# Altium schematic sheet Microcontroller.SchDoc
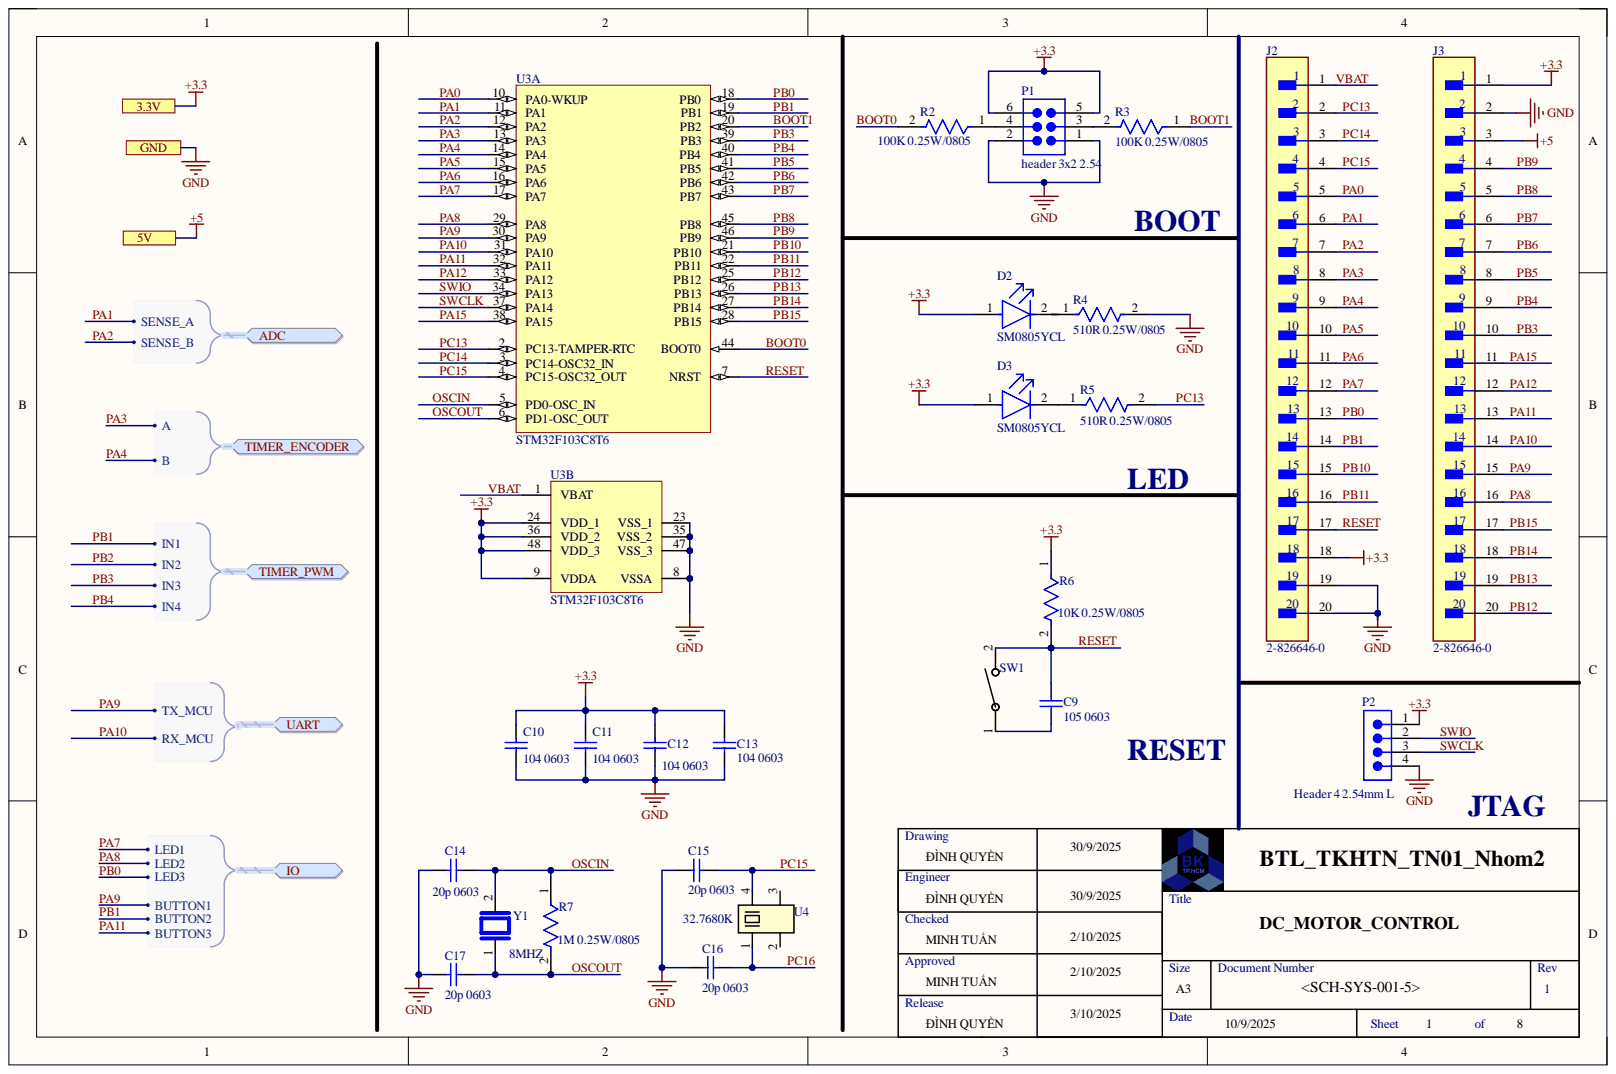
[diagram p0: Microcontroller.SchDoc]

## Parts
C9  105 0603  [CAPC1608X92X38ML15T08]
C10  104 0603  [CAPC1608X92X38ML15T08]
C11  104 0603  [CAPC1608X92X38ML15T08]
C12  104 0603  [CAPC1608X92X38ML15T08]
C13  104 0603  [CAPC1608X92X38ML15T08]
C14  20p 0603  [CAPC1608X92X38ML15T08]
C15  20p 0603  [CAPC1608X92X38ML15T08]
C16  20p 0603  [CAPC1608X92X38ML15T08]
C17  20p 0603  [CAPC1608X92X38ML15T08]
D2  SM0805YCL  [FP-SM0805YCL-MFG]
D3  SM0805YCL  [FP-SM0805YCL-MFG]
J2  2-826646-0  [FP-2-826646-0-MFG]
J3  2-826646-0  [FP-2-826646-0-MFG]
P1  header 3x2 2.54  [SMTC-TSW-103-08-X-D]
P2  Header 4 2.54mm L  [SMTC-TSW-104-08-X-S]
R2  100K 0.25W/0805  [FP-AF0805-IPC_C]
R3  100K 0.25W/0805  [FP-AF0805-IPC_C]
R4  510R 0.25W/0805  [FP-AF0805-IPC_C]
R5  510R 0.25W/0805  [FP-AF0805-IPC_C]
R6  10K 0.25W/0805  [FP-AF0805-IPC_C]
R7  1M 0.25W/0805  [FP-AF0805-IPC_C]
SW1  PTS636 SM50J SMTR LFS  [SW_PTS636_CNK]  (value not drawn)
U3  STM32F103C8T6  [STM-LQFP48_L]  (parts A,B)
U4  32.7680K  [SOJ_MC-306_EPS]
Y1  8MHZ  [XTAL_HC49P488W43L1150T466H350]

## Ports
3.3V, 5V, ADC, ADC.SENSE_A, ADC.SENSE_B, GND, IO, IO.BUTTON1, IO.BUTTON2, IO.BUTTON3, IO.LED1, IO.LED2, IO.LED3, TIMER_ENCODER, TIMER_ENCODER.A, TIMER_ENCODER.B, TIMER_PWM, TIMER_PWM.IN1, TIMER_PWM.IN2, TIMER_PWM.IN3, TIMER_PWM.IN4, UART, UART.RX_MCU, UART.TX_MCU

## Nets
+3.3: C10.2 C11.2 C12.2 C13.2 D2.1 D3.1 J2.18 J3.1 P1.5 P1.6 P2.1 R6.1 U3.9(VDDA) U3.24(VDD_1) U3.36(VDD_2) U3.48(VDD_3) ; port 3.3V
+5: J3.3 ; port 5V
BOOT0: R2.2 U3.44(BOOT0)
BOOT1: R3.1 U3.20(PB2)
GND: C10.1 C11.1 C12.1 C13.1 C14.2 C15.2 C16.2 C17.2 J2.19 J2.20 J3.2 P1.1 P1.2 P2.4 R4.2 U3.8(VSSA) U3.23(VSS_1) U3.35(VSS_2) U3.47(VSS_3) ; port GND
OSCIN: C14.1 R7.1 U3.5(PD0-OSC_IN) Y1.2
OSCOUT: C17.1 R7.2 U3.6(PD1-OSC_OUT) Y1.1
PA0: J2.5 U3.10(PA0-WKUP)
PA1: J2.6 U3.11(PA1) ; port ADC
PA2: J2.7 U3.12(PA2) ; port ADC
PA3: J2.8 U3.13(PA3) ; port TIMER_ENCODER
PA4: J2.9 U3.14(PA4) ; port TIMER_ENCODER
PA5: J2.10 U3.15(PA5)
PA6: J2.11 U3.16(PA6)
PA7: J2.12 U3.17(PA7) ; port IO
PA8: J3.16 U3.29(PA8) ; port IO
PA9: J3.15 U3.30(PA9) ; port IO, port UART
PA10: J3.14 U3.31(PA10) ; port UART
PA11: J3.13 U3.32(PA11) ; port IO
PA12: J3.12 U3.33(PA12)
PA15: J3.11 U3.38(PA15)
PB0: J2.13 U3.18(PB0) ; port IO
PB1: J2.14 U3.19(PB1) ; port IO, port TIMER_PWM
PB3: J3.10 U3.39(PB3) ; port TIMER_PWM
PB4: J3.9 U3.40(PB4) ; port TIMER_PWM
PB5: J3.8 U3.41(PB5)
PB6: J3.7 U3.42(PB6)
PB7: J3.6 U3.43(PB7)
PB8: J3.5 U3.45(PB8)
PB9: J3.4 U3.46(PB9)
PB10: J2.15 U3.21(PB10)
PB11: J2.16 U3.22(PB11)
PB12: J3.20 U3.25(PB12)
PB13: J3.19 U3.26(PB13)
PB14: J3.18 U3.27(PB14)
PB15: J3.17 U3.28(PB15)
PC13: J2.2 R5.2 U3.2(PC13-TAMPER-RTC)
PC14: J2.3 U3.3(PC14-OSC32_IN)
PC15: C15.1 J2.4 U3.4(PC15-OSC32_OUT) U4.4
PC16: C16.1 U4.1
RESET: C9.2 J2.17 R6.2 SW1.2 U3.7(NRST)
SWCLK: P2.3 U3.37(PA14)
SWIO: P2.2 U3.34(PA13)
VBAT: J2.1 U3.1(VBAT)
NetC9_1: C9.1 SW1.1
NetD2_2: D2.2
NetD3_2: D3.2 R5.1
NetP1_3: P1.3 R3.2
NetP1_4: P1.4 R2.1
NetR4_1: R4.1
NetU4_2: U4.2
NetU4_3: U4.3
PB2: ; port TIMER_PWM

## Library data of the parts
C9-C17 = CMP-2006-01201-1: Manufacturer: Vitramon; Part Number: VJ0603Y103JXXAC; Component Kind: Standard; Library Name: Altium Content Vault
D2 D3 = CMP-35283-000007-1: LED YELLOW CLEAR 0805 SMD; Dominant Wavelength: 592nm; Forward Current: 2mA; Forward Voltage: 1.9V; Lens Color: Colorless; Luminous Intensity: 11.5mcd; Viewing Angle: 130°; Case Code (Imperial): 0805; Case Code (Metric): 2012; Case/Package: 0805; Color: Yellow; Component Kind: Standard; Height: 0.8mm; Illumination Color: Yellow; Lead Free: Lead Free; Length: 2mm; Lens Transparency: Clear; Library Name: Altium Content Vault; Max Operating Temperature: 80°C; Min Operating Temperature: -30°C; Mount: Surface Mount; Package Quantity: 4000; Packaging: Tape and Reel; Test Current: 2mA; Width: 1.2mm
J2 J3 = CMP-03369-000139-1: CONN HEADER VERT 20POS 2.54MM; Board Standoff: No (Without); Connector Type: Plug; Contact Post Length: 0.1259inch; Current Rating: 3A; High Speed Serial Data Connector: No; Number of Contacts: 20; PCB Mount Retention: Without; PCB Mounting Orientation: Vertical; Plating Thickness: 0.8um; Color: Green; Component Kind: Standard; Contact Gender: Male; Contact Material: Bronze; Contact Mating Length: 5.8mm; Contact Plating: Gold; Contact Protection: No (Without); Contact Shape: Square; Contact Type: Male Pin; Depth: 2.5mm; ELV: Compliant; Flammability Rating: UL94 V-0; Gasket: No (Without); High Temperature Housing: No; Housing Color: Green; Housing Material: PBT; Keyed: No; Length: 50.8mm; Library Name: Altium Content Vault; Mating Alignment: No (Without); Mating Connector Lock: No (Without); Max Operating Temperature: 105°C; Min Operating Temperature: -65°C; Mount: Straddle Mount; Number of Positions: 20; Number of Rows: 1; Orientation: Straight; Package Quantity: 300; Packaging: Carton; Pitch: 2.54mm; Radiation Hardening: No; RoHS Compliant: Yes; Row Spacing: 2.54mm; Selectively Loaded: No (Without); Series: AMPMODU MOD II; Stabilizers: No (Without); Strain Relief: No (Without); Termination: Through Hole
P1 = CMP-1024-00337-1: 0.025" SQ Post Header, Through-hole, Vertical, -55 to 125 degC, 2.54 mm Pitch, 6-Pin, Male, RoHS; Manufacturer: Samtec; PartNumber: TSW-103-08-F-D; Pitch: 2.54 mm; Component Kind: Standard; ComponentLink1Description: Manufacturer URL; ComponentLink1URL: http://www.samtec.com; ComponentLink2Description: Datasheet; ComponentLink2URL: http://www.samtec.com/documents/webfiles/pdf/TSW_TH.PDF; ComponentLink3Description: Package Specification; ComponentLink3URL: http://www.samtec.com/documents/webfiles/cpdf/TSW-XXX-XX-XXX-X-XX-XXX-MKT.pdf; DatasheetVersion: F-214; Library Name: Altium Content Vault; Mounting Technology: Through-hole; Orientation: Vertical; PackageDescription: .025[0.64] SQ. Post Terminal Strip Assembly; PackageReference: TSW-103-08-X-D; PackageVersion: DM, 8/1988; RoHS: True; Temperature Range: -55 to 125 degC
P2 = CMP-1024-00357-1: 0.025" SQ Post Header, Through-hole, Vertical, -55 to 125 degC, 2.54 mm Pitch, 4-Pin, Male, RoHS; Manufacturer: Samtec; PartNumber: TSW-104-08-G-S; Component Kind: Standard; ComponentLink1Description: Manufacturer URL; ComponentLink1URL: http://www.samtec.com; ComponentLink2Description: Datasheet; ComponentLink2URL: http://www.samtec.com/documents/webfiles/pdf/TSW_TH.PDF; ComponentLink3Description: Package Specification; ComponentLink3URL: http://www.samtec.com/documents/webfiles/cpdf/TSW-XXX-XX-XXX-X-XX-XXX-MKT.pdf; DatasheetVersion: F-214; Library Name: Altium Content Vault; Mounting Technology: Through-hole; Orientation: Vertical; PackageDescription: .025[0.64] SQ. Post Terminal Strip Assembly; PackageReference: TSW-104-08-X-S; PackageVersion: DM, 8/1988; Pitch: 2.54 mm; RoHS: True; Temperature Range: -55 to 125 degC
R2-R7 = CMP-03412-045285-1: Anti-Sulfurated Chip Resistor Automotive Grade 75Ω 1% 0.125W ±100ppm/°C 0805; Max Power Dissipation: 125mW; Resistance: 75R; Case/Package: 0805; Component Kind: Standard; Features: Moisture Resistant; Height: 0.024inch; Length: 0.079inch; Library Name: Altium Content Vault; Max Operating Temperature: 155°C; Min Operating Temperature: -55°C; Number of Terminations: 2; Packaging: Tape and Reel; Resistor Type: Thick Film; RoHS Compliant: Yes; Series: AF; Temperature Coefficient: 100ppm/°C; Tolerance: 1%; Width: 0.049inch
SW1 = PTS636 SM50J SMTR LFS: No Description Available; Manufacturer_Name: C&K Components; Component Kind: Standard; Library Name: PTS636SM50JSMTRLFS.IntLib; Manufacturer_Part_Number: PTS636 SM50J SMTR LFS; Type: Tactile Switches; Copyright: Copyright (C) 2025 Ultra Librarian. All rights reserved.
U3 = CMP-0237-00215-3: ARM Cortex-M3 32-bit MCU, 64 KB Flash, 20 KB Internal RAM, 37 I/Os, 48-pin LQFP, -40 to 85 degC, Tray; A/D Converter: 2x12-bit (10 Channel); FLASH Size (Prog) (kB): 64; I/Os (High Current): 37; Internal RAM Size (kB): 20; Operating Frequency(F) (Processor speed) (MHz): 72; Supply Voltage(Vcc) min (V): 2; Component Kind: Standard; ComponentLink1Description: Manufacturer URL; ComponentLink1URL: http://www.st.com/web/en/home.html; ComponentLink2Description: Datasheet; ComponentLink2URL: http://www.st.com/st-web-ui/static/active/en/resource/technical/document/datasheet/CD00161566.pdf; ComponentLink3Description: Package Specification; ComponentLink3URL: http://www.st.com/st-web-ui/static/active/en/resource/technical/document/datasheet/DM00058181.pdf; Core: ARM Cortex-M3; DatasheetVersion: Rev. 16; Library Name: Altium Content Vault; Mounting Technology: Surface Mount; PackageDescription: 48-Pin Low Profile Quad Flat Package, 7 x 7 mm Body, 0.5 mm Pitch; PackageReference: LQFP48; PackageVersion: Rev. 6, 06/2013; Packing: Tray; PartNumber: STM32F103C8T6
U4 = MC-306 32.7680K-A3: ROHS: No Description Available; Manufacturer_Name: Epson; Component Kind: Standard; Manufacturer_Part_Number: MC-306 32.7680K-A3: ROHS; RefDes: RefDes; Type: Type; Copyright: Copyright (C) 2025 Ultra Librarian. All rights reserved.; Library Name: MC-30632P7680K-A3&colon_ROHS.IntLib
Y1 = HC-49/U-S16000000ABJB: 16 MHz Â±30ppm Crystal 18pF 50 Ohms HC-49/US; MF: Citizen Finedevice; Check_prices: https://www.snapeda.com/parts/HC-49/U-S16000000ABJB/Citizen/view-part/?ref=eda; Component Kind: Standard; Library Name: HC-49_U-S16000000ABJB.IntLib; MP: HC-49/U-S16000000ABJB; Package: HC-49/US Citizen Finetech Miyota; SnapEDA_Link: https://www.snapeda.com/parts/HC-49/U-S16000000ABJB/Citizen/view-part/?ref=snap
